# Altium schematic sheet VT320.SchDoc
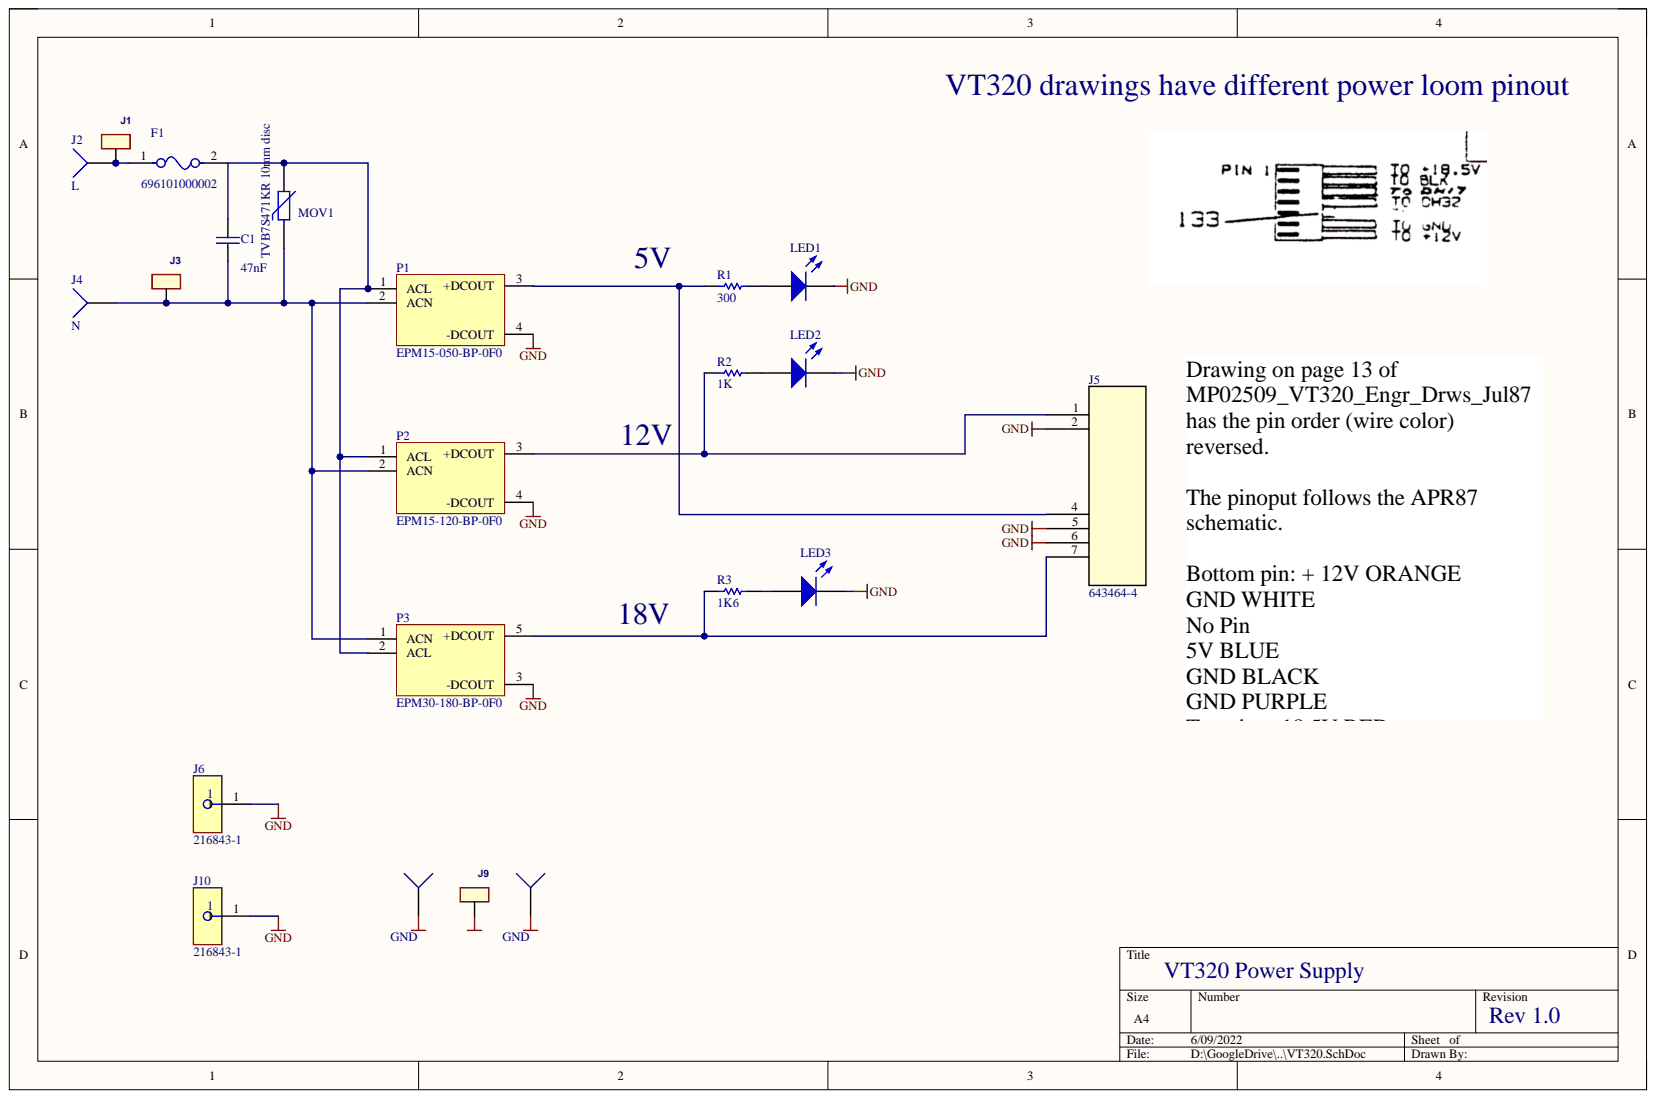
[diagram p0: VT320.SchDoc]

## Parts
C1  value 47nF  [MKP-3381-15]
F1  696101000002  [FP-696101000002-MFG]
J1  Binding Post  [KEYSTONE 8737]  (value not drawn)
J2  L  [TP-THT-MULTI]  (no BOM)
J3  Binding Post  [KEYSTONE 8737]  (value not drawn)
J4  N  [TP-THT-MULTI]  (no BOM)
J5  643464-4  [643464-4]
J6  216843-1  [FP-216843-1-MFG]
J7  GND  [TP-THT-MULTI]  (no BOM)
J8  GND  [TP-THT-MULTI]  (no BOM)
J9  Binding Post  [KEYSTONE 8737]  (value not drawn)
J10  216843-1  [FP-216843-1-MFG]
LED1  151051RS11000  [Type 5mm]  (value not drawn)
LED2  151051RS11000  [Type 5mm]  (value not drawn)
LED3  151051RS11000  [Type 5mm]  (value not drawn)
MOV1  TVB7S471KR 10mm disc  P/N: TVB7S471KR  [TVR1010]
P1  EPM15-050-BP-0F0  [EPM15-120-BP-0F0]
P2  EPM15-120-BP-0F0  [EPM15-120-BP-0F0]
P3  EPM30-180-BP-0F0  [EPM30-180-BP-0F0]
R1  300  [RESA60-630X240]
R2  1K  [RESA60-630X240]
R3  1K6  [RESA60-630X240]

## Nets
GND: J5.2 J5.5 J5.6 J6.1 J7.1 J8.1 J9.1 J10.1 LED1.1 LED2.1 LED3.1 P1.4(-DCOUT) P2.4(-DCOUT) P3.3(-DCOUT)
NetC1_1: C1.1 J3.1 J4.1 MOV1.2 P1.2(ACN) P2.2(ACN) P3.1(ACN)
NetC1_2: C1.2 F1.2 MOV1.1 P1.1(ACL) P2.1(ACL) P3.2(ACL)
NetF1_1: F1.1 J1.1 J2.1
NetJ5_1: J5.1 P2.3(+DCOUT) R2.1
NetJ5_4: J5.4 P1.3(+DCOUT) R1.1
NetJ5_7: J5.7 P3.5(+DCOUT) R3.1
NetLED1_2: LED1.2 R1.2
NetLED2_2: LED2.2 R2.2
NetLED3_2: LED3.2 R3.2

## Library data of the parts
C1 = Cap: Capacitor; P/N: B32922C3473K189; Component Kind: Standard; LatestRevisionDate: 17-Jul-2002; LatestRevisionNote: Re-released for DXP Platform.; Library Name: Miscellaneous Devices.IntLib; PackageReference: RAD-0.3; Published: 8-Jun-2000; Signal Integrity: Cap; Simulation: CAP; Publisher: Altium Limited
F1 = CMP-1502-00233-2: FUSEHOLDER BLOCKS - PCB - CLIP C; Current Rating: 10A; Fuse Size: 0.197 Dia x 0.787 L (5mm x 20mm); Number of Circuits: 1; Voltage: 250V; Component Kind: Standard; Contact Material: Copper Alloy; Contact Plating: Tin; Fuse Current: 10A; Library Name: Altium Content Vault; Mount: Through Hole; Orientation: Horizontal; P/N: 696101000002; RoHS Compliant: Yes; Series: -; Termination: PC Pin; Voltage Rating (AC): 250V
J1 J3 J9 = Keystone 8737: Component Kind: Standard; P/N: Keystone 8737; Library Name: Kanitti.SchLib
J2 J4 J7 J8 = Socket: Description: Socket; LatestRevisionDate: 17-Jul-2002; LatestRevisionNote: Re-released for DXP Platform.; Library Name: Miscellaneous Connectors.IntLib; Signal Integrity: Connector; Publisher: Altium Limited
J5 = 643464-4: VERT HDR 7PIN 3.96MM W/O PIN 3; Component Kind: Standard; P/N: 643464-4; Library Name: Kanitti.SchLib
J6 J10 = CMP-03369-000001-1: CONN QC TAB 0.250 PRESS-FIT; Manufacturer: TE; Conn Gender: Female; Conn Orientation: Straight; Is Female: yes; Number Of Contacts: 1; Automotive: No; Category: Conn; Component Kind: Standard; ComponentLink2Description: Datasheet; ComponentLink2URL: https://www.te.com/usa-en/product-216843-1.html?te_bu=Cor&te_type=other&te_campaign=oct_glo_cor-oct-global-oth-prtnr-fy19-oct-octo-buy-bom_sma-298_2&elqCampaignId=35216; Contact Material: Tin; Device Class L1: Connectors; Device Class L2: Headers and Wire Housings; Device Class L3: unset; Digikey Description: CONN QC TAB 0.250 PRESS-FIT; Footprint Url: https://www.te.com/commerce/DocumentDelivery/DDEController?Action=showdoc&DocId=Customer+Drawing%7F216843%7FB1%7Fpdf%7FGerman%7FGER_CD_216843_B1.pdf%7F216843-1; Height: 13.5mm; Lead Free: Yes; Library Name: Altium Content Vault; Manufacturer Part Number: 216843-1; Mouser Description: Terminals .250 POS LOCK TAB; Octopart: https://octopart.com/216843-1-te+connectivity-46512127; P/N: 216843-1; Package: 216843-1; Temperature: -30°C to +110°C; Temperature Range High: +110°C; Temperature Range Low: -30°C
LED1-LED3 = CMP-1488-00008-1: THT LED round mono-color color lens, WL-TMRW, Red; Manufacturer: Wuerth Elektronik; Component Kind: Standard; ComponentLink1Description: Manufacturer URL; ComponentLink1URL: http://www.we-online.com; ComponentLink2Description: Datasheet; ComponentLink2URL: http://katalog.we-online.de/pbs/datasheet/7448042001.pdfhttp://katalog.we-online.de/pbs/datasheet/7448042001.pdfhttp://katalog.we-online.de/pbs/datasheet/7448042001.pdfhttp://katalog.we-online.de/pbs/datasheet/151051RS11000.pdf; Emitting color: Red; Library Name: Altium Content Vault; P/N: 151051RS11000; PackageReference: Type 5mm; PartNumber: 151051RS11000
MOV1 = VARISTOR: Component Kind: Standard
R1 = CMP-1659-00072-1: Axial Resistor, 221 Ohm, +/- 1%, 250 mW, -55 to 155 degC, 2-Pin THD, RoHS, Bulk; Bandwidth: 800 Hz; Case\Package: QFN; Interface: I2C; Max Supply Voltage: 3.6 V; Min Supply Voltage: 1.95 V; Nominal Supply Voltage: 2.5 V; P/N: MFR-25FRF52-300R; Component Kind: Standard; ComponentLink1Description: Manufacturer URL; ComponentLink1URL: http://www.yageo.com/; ComponentLink2Description: Datasheet; ComponentLink2URL: http://www.yageo.com/documents/recent/Yageo%20LR_MFR_2013.pdf; DatasheetVersion: Apr-13; Height: 0.95 mm; Length: 3 mm; Library Name: Altium Content Vault; Max Operating Temperature: 85 degC; Min Operating Temperature: -40 degC; Mount: Surface Mount; Package Quantity: 1000; PackageDescription: 2-Pin Axial Lead, Dia 2.4 mm, Body Length 6.3 mm; PackageReference: MFR-25; Packaging: Tape and Reel; Pins: 16; Radiation Hardening: No; REACH SVHC: No SVHC; RoHSCompliant: true; Weight: 0.001122 oz
R2 = CMP-1659-00072-1: Axial Resistor, 221 Ohm, +/- 1%, 250 mW, -55 to 155 degC, 2-Pin THD, RoHS, Bulk; Bandwidth: 800 Hz; Case\Package: QFN; Interface: I2C; Max Supply Voltage: 3.6 V; Min Supply Voltage: 1.95 V; Nominal Supply Voltage: 2.5 V; P/N: XYCON 271-1K/AP-RC; Component Kind: Standard; ComponentLink1Description: Manufacturer URL; ComponentLink1URL: http://www.yageo.com/; ComponentLink2Description: Datasheet; ComponentLink2URL: http://www.yageo.com/documents/recent/Yageo%20LR_MFR_2013.pdf; DatasheetVersion: Apr-13; Height: 0.95 mm; Length: 3 mm; Library Name: Altium Content Vault; Max Operating Temperature: 85 degC; Min Operating Temperature: -40 degC; Mount: Surface Mount; Package Quantity: 1000; PackageDescription: 2-Pin Axial Lead, Dia 2.4 mm, Body Length 6.3 mm; PackageReference: MFR-25; Packaging: Tape and Reel; Pins: 16; Radiation Hardening: No; REACH SVHC: No SVHC; RoHSCompliant: true; Weight: 0.001122 oz
R3 = CMP-1659-00072-1: Axial Resistor, 221 Ohm, +/- 1%, 250 mW, -55 to 155 degC, 2-Pin THD, RoHS, Bulk; Bandwidth: 800 Hz; Case\Package: QFN; Interface: I2C; Max Supply Voltage: 3.6 V; Min Supply Voltage: 1.95 V; Nominal Supply Voltage: 2.5 V; P/N: MFR-25FRF52-1K6; Component Kind: Standard; ComponentLink1Description: Manufacturer URL; ComponentLink1URL: http://www.yageo.com/; ComponentLink2Description: Datasheet; ComponentLink2URL: http://www.yageo.com/documents/recent/Yageo%20LR_MFR_2013.pdf; DatasheetVersion: Apr-13; Height: 0.95 mm; Length: 3 mm; Library Name: Altium Content Vault; Max Operating Temperature: 85 degC; Min Operating Temperature: -40 degC; Mount: Surface Mount; Package Quantity: 1000; PackageDescription: 2-Pin Axial Lead, Dia 2.4 mm, Body Length 6.3 mm; PackageReference: MFR-25; Packaging: Tape and Reel; Pins: 16; Radiation Hardening: No; REACH SVHC: No SVHC; RoHSCompliant: true; Weight: 0.001122 oz
P1 = EPM15-050-BP-0F0: Component Kind: Standard; P/N: EPM15-050-BP-0F0; Library Name: Kanitti.SchLib
P2 = EPM15-120-BP-0F0: Component Kind: Standard; P/N: EPM15-120-BP-0F0; Library Name: Kanitti.SchLib
P3 = EPM30-180-BP-0F0: Component Kind: Standard; P/N: EPM30-180-BP-0F0; Library Name: Kanitti.SchLib
